# Altium schematic sheet SerialConverter.SchDoc(SerialConverter)
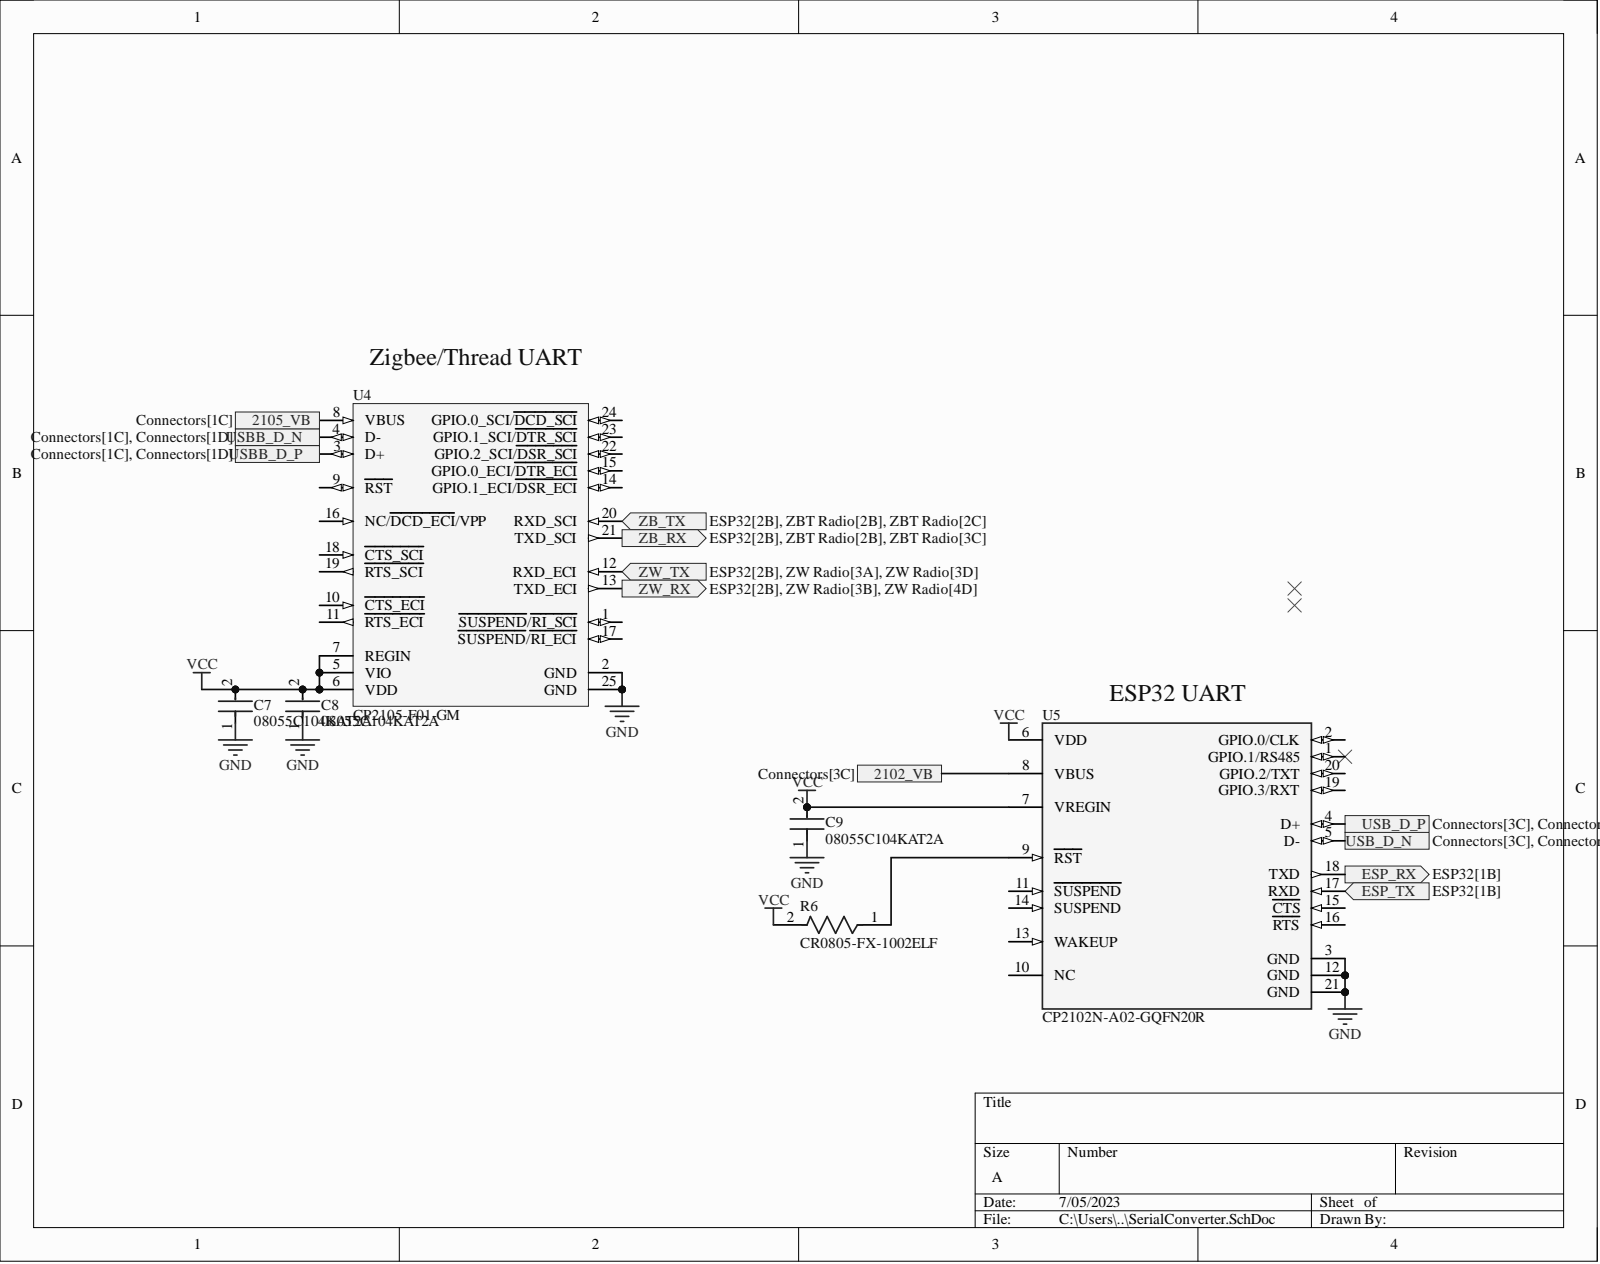
[diagram p0: SerialConverter.SchDoc]

## Parts
C7  08055C104KAT2A  [FP-0805-L_2_01_0_2-W_1_25-IPC_C]
C8  08055C104KAT2A  [FP-0805-L_2_01_0_2-W_1_25-IPC_C]
C9  08055C104KAT2A  [FP-0805-L_2_01_0_2-W_1_25-IPC_C]
R6  CR0805-FX-1002ELF  [FP-CR0805-IPC_A]
U4  CP2105-F01-GM  [SLAB-QFN-24-2675x2675TP_N]
U5  CP2102N-A02-GQFN20R  [FP-CP2102N-A02-GQFN20R-MFG]

## Ports
2102_VB, 2105_VB, ESP_RX, ESP_TX, USB_D_N, USB_D_P, USBB_D_N, USBB_D_P, ZB_RX, ZB_TX, ZW_RX, ZW_TX

## Nets
GND: C7.1 C8.1 C9.1 U4.2(GND) U4.25(GND) U5.3(GND) U5.12(GND) U5.21(GND)
VCC: C7.2 C8.2 C9.2 R6.2 U4.5(VIO) U4.6(VDD) U4.7(REGIN) U5.6(VDD) U5.7(VREGIN)
2102_VB: U5.8(VBUS) ; port 2102_VB
2105_VB: U4.8(VBUS) ; port 2105_VB
ESP_RX: U5.18(TXD) ; port ESP_RX
ESP_TX: U5.17(RXD) ; port ESP_TX
USB_D_N: U5.5(D-) ; port USB_D_N
USB_D_P: U5.4(D+) ; port USB_D_P
USBB_D_N: U4.4(D-) ; port USBB_D_N
USBB_D_P: U4.3(D+) ; port USBB_D_P
ZB_RX: U4.21(TXD_SCI) ; port ZB_RX
ZB_TX: U4.20(RXD_SCI) ; port ZB_TX
ZW_RX: U4.13(TXD_ECI) ; port ZW_RX
ZW_TX: U4.12(RXD_ECI) ; port ZW_TX
NetR6_1: R6.1 U5.9(RST)

Not connected: U4 1(SUSPEND/RI_SCI) 9(RST) 10(CTS_ECI) 11(RTS_ECI) 14(GPIO.1_ECI/DSR_ECI) 15(GPIO.0_ECI/DTR_ECI) 16(NC/DCD_ECI/VPP) 17(SUSPEND/RI_ECI) 18(CTS_SCI) 19(RTS_SCI) 22(GPIO.2_SCI/DSR_SCI) 23(GPIO.1_SCI/DTR_SCI) 24(GPIO.0_SCI/DCD_SCI); U5 1(GPIO.1/RS485) 2(GPIO.0/CLK) 10(NC) 11(SUSPEND) 13(WAKEUP) 14(SUSPEND) 15(CTS) 16(RTS) 19(GPIO.3/RXT) 20(GPIO.2/TXT)

## Library data of the parts
C7-C9 = CMP-2000-05016-2: General Purpose Ceramic Capacitor, 0805, 100nF, 10%, X7R, 0.15, 50V; Dissipation Factor: 2.5%; Insulation Resistance: 100GR; Capacitance: 100nF; Case Code (Imperial): 0805; Case Code (Metric): 2012; Case/Package: 0805; Component Kind: Standard; Depth: 1.25mm; Dielectric: X7R; Dielectric Material: Ceramic; Height: 0.5mm; Lead Free: Lead Free; Length: 2mm; Library Name: Altium Content Vault; Max Operating Temperature: 125°C; Min Operating Temperature: -55°C; Mount: Surface Mount; Number of Pins: 2; Package Quantity: 4000; Packaging: Cut Tape; Radiation Hardening: No; REACH SVHC: No SVHC; RoHS Compliant: Yes; Series: MLCC X7R; Termination: Standard; Thickness: 0.037inch; Tolerance: 10%; Voltage: 50V; Voltage Rating: 50V; Voltage Rating (DC): 50V; Weight: 0.001411oz; Width: 1.2mm
R6 = CMP-07231-003204-1: Thick Film Chip Resistors 0805 10kΩ 0.125W 1% 100ppm/°C; Max Power Dissipation: 125mW; Resistance: 10kR; Voltage Rating: 150V; Voltage Rating (DC): 100V; Case Code (Imperial): 0805; Case Code (Metric): 2012; Case/Package: 0805; Component Kind: Standard; Composition: Thick Film; Contact Plating: Tin; Depth: 1.25mm; Features: Moisture Resistant; Height: 0.5mm; Length: 2mm; Library Name: Altium Content Vault; Max Operating Temperature: 155°C; Min Operating Temperature: -55°C; Mount: Surface Mount; Number of Terminations: 2; Package Quantity: 1; Packaging: Tape and Reel; Power Rating: 125mW; Radiation Hardening: No; REACH SVHC: No SVHC; Temperature Coefficient: 100ppm/°C; Termination: SMD/SMT; Thickness: 508um; Tolerance: 1%; Width: 1.25mm
U4 = CMP-0511-00003-1: Single-Chip USB to Dual UART Bridge, 5 Dig I/O Pins, Internal Oscillator, 296 Bytes EEPROM, -40 to 85 degC, 24-pin QFN; Manufacturer: Silicon Labs; Internal Oscillator: TRUE; Sub-Family: USB Bridges; Code_IPC: J-STD-020; Code_JEDEC: MO-220; Component Kind: Standard; ComponentLink1Description: Manufacturer URL; ComponentLink1URL: http://www.silabs.com/; ComponentLink2Description: Datasheet; ComponentLink2URL: http://www.silabs.com/Support%20Documents/TechnicalDocs/CP2105.pdf; ComponentLink3Description: Package Specification; ComponentLink3URL: http://www.silabs.com/Support%20Documents/TechnicalDocs/C8051F93x-92x.pdf; DatasheetVersion: Rev. 1.0; EEPROM: 296 Bytes; Library Name: Altium Content Vault; Mounting Technology: Surface Mount; PackageDescription: 24-Pin Quad-Flat No-Leads Package, Body 4 x 4 mm, Pitch 0.5 mm; PackageReference: QFN-24; PartNumber: CP2105-F01-GM
U5 = CMP-08107-000007-1: IC USB TO UART BRIDGE QFN20; Component Kind: Standard; Library Name: Altium Content Vault
